# Altium schematic sheet uInverter.SchDoc(uInverter)
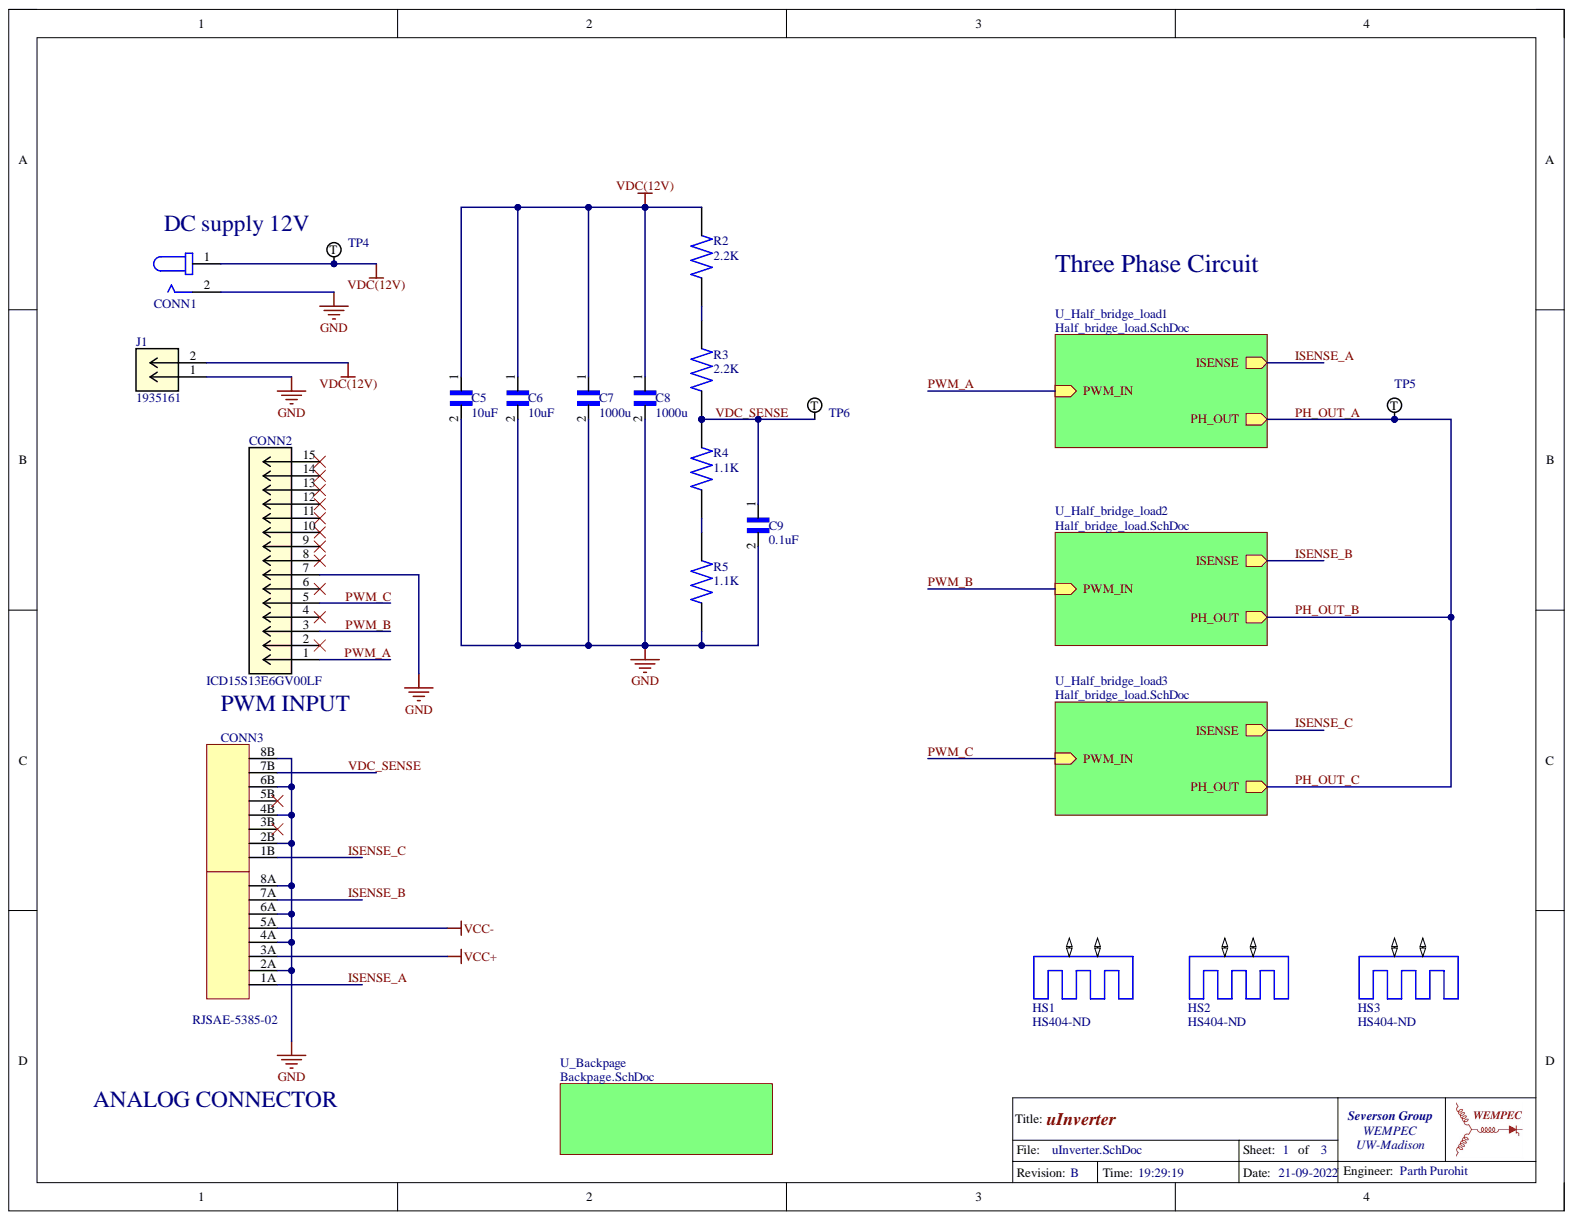
[diagram p0: uInverter.SchDoc]

## Parts
C5  10uF  [0805_CAP]
C6  10uF  [0805_CAP]
C7  1000u  [CAP_PAN_12.5X25_PAN]
C8  1000u  [CAP_PAN_12.5X25_PAN]
C9  0.1uF  [0805_CAP]
CONN1  PJ-037AH  [CUI_PJ-037AH]  (value not drawn)
CONN2  ICD15S13E6GV00LF  [ICD15S13E6GV00LF]
CONN3  RJSAE-5385-02  [CONN_RJSAE538502_AMP]
HS1  Supplier Part Number 1: HS404-ND  [530002]  (hidden comment: 529702B02500G)
HS2  Supplier Part Number 1: HS404-ND  [530002]  (hidden comment: 529702B02500G)
HS3  Supplier Part Number 1: HS404-ND  [530002]  (hidden comment: 529702B02500G)
J1  1935161  [PHOENIX_1935161]
R2  2.2K  [0805_RES]
R3  2.2K  [0805_RES]
R4  1.1K  [0805_RES]
R5  1.1K  [0805_RES]
TP4  Test Point  (value not drawn)
TP5  Test Point  (value not drawn)
TP6  Test Point  (value not drawn)

## Nets
GND: C5.2 C6.2 C7.2 C8.2 C9.2 CONN1.2 CONN2.7 CONN3.2A CONN3.2B CONN3.4A CONN3.4B CONN3.6A CONN3.6B CONN3.8A CONN3.8B J1.1 R5.1
VCC+: CONN3.3A
VCC-: CONN3.5A
VDC(12V): C5.1 C6.1 C7.1 C8.1 CONN1.1 J1.2 R2.2 TP4.1
ISENSE_A: CONN3.1A
ISENSE_B: CONN3.7A
ISENSE_C: CONN3.1B
PH_OUT_A: TP5.1
PWM_A: CONN2.1
PWM_B: CONN2.3
PWM_C: CONN2.5
VDC_SENSE: C9.1 CONN3.7B R3.1 R4.2 TP6.1
NetCONN2_2: CONN2.2
NetCONN2_4: CONN2.4
NetCONN2_6: CONN2.6
NetCONN2_8: CONN2.8
NetCONN2_9: CONN2.9
NetCONN2_10: CONN2.10
NetCONN2_11: CONN2.11
NetCONN2_12: CONN2.12
NetCONN2_13: CONN2.13
NetCONN2_14: CONN2.14
NetCONN2_15: CONN2.15
NetCONN3_3B: CONN3.3B
NetCONN3_5B: CONN3.5B
NetHS1_P$1: HS1.P$1
NetHS1_P$2: HS1.P$2
NetHS2_P$1: HS2.P$1
NetHS2_P$2: HS2.P$2
NetHS3_P$1: HS3.P$1
NetHS3_P$2: HS3.P$2
NetR2_1: R2.1 R3.2
NetR4_1: R4.1 R5.2

## Library data of the parts
C5 C6 = GRM21BR6YA106KE43L: 0805 35 V 10 uF ±10% Tolerance X5R Multilayer Ceramic Capacitor; Manufacturer: Murata; Voltage Rating: 35V; Capacitance: 10uF; Case Code (Imperial): 0805; Case Code (Metric): 2012; Case/Package: 0805; Category: Ceramic Capacitors; Component Kind: Standard; ComponentLink1Description: http://www.farnell.com/datasheets/2079187.pdf?src-supplier=Farnell; ComponentLink1URL: http://www.farnell.com/datasheets/2079187.pdf?src-supplier=Farnell; ComponentLink2Description: http://www.mouser.com/ds/2/281/c02e-2905.pdf?src-supplier=Mouser; ComponentLink2URL: http://www.mouser.com/ds/2/281/c02e-2905.pdf?src-supplier=Mouser; ComponentLink3Description: http://www.farnell.com/datasheets/2079187.pdf?src-supplier=Element14; ComponentLink3URL: http://www.farnell.com/datasheets/2079187.pdf?src-supplier=Element14; ComponentLink4Description: http://search.murata.co.jp/Ceramy/image/img/A01X/G101/ENG/GRM21BR6YA106KE43-01.pdf?src-supplier=Digi-Key; ComponentLink4URL: http://search.murata.co.jp/Ceramy/image/img/A01X/G101/ENG/GRM21BR6YA106KE43-01.pdf?src-supplier=Digi-Key; ComponentLink5Description: http://search.murata.co.jp/Ceramy/image/img/A01X/partnumbering_e_01.pdf?src-supplier=Digi-Key; ComponentLink5URL: http://search.murata.co.jp/Ceramy/image/img/A01X/partnumbering_e_01.pdf?src-supplier=Digi-Key; ComponentLink6Description: http://www.farnell.com/datasheets/2079187.pdf?src-supplier=Newark; ComponentLink6URL: http://www.farnell.com/datasheets/2079187.pdf?src-supplier=Newark; Dielectric: X5R; Height: 1.25mm; Lead Free: Lead Free; Length: 0.079inch; Library Name: Schlib_uInverter.SchLib; Manufacturer Part Number: GRM21BR6YA106KE43L; Max Operating Temperature: 85°C; Min Operating Temperature: -55°C; Mount: Surface Mount; Package Quantity: 3000; Packaging: Tape and Reel; Pricing 1: 3000=0.12929, 6000=0.12211, 9000=0.11493, 15000=0.11134, 30000=0.10775, 75000=0.10056 (USD); REACH SVHC: No SVHC; RoHS: Yes; RoHS Compliant: Yes; Stock 1: 12398; Supplier 1: Digi-Key; Supplier Part Number 1: 490-10514-2-ND; Termination: SMD/SMT; Thickness: 0.057inch; Tolerance: 10%; Voltage Rating (DC): 35V; Weight: 0.000335oz; Width: 0.049inch
C7 C8 = EEU-FM1V102B: Cap Aluminum 1000uF 35V 20% (12.5 X 25mm) Radial 5mm 3190mA 7000 hr 105C Ammo Pack; Manufacturer: Panasonic; Capacitance: 1mF; ESR (Equivalent Series Resistance): 15mR; Lead Diameter: 0.6mm; Life (Hours): 7000Hour; Polarity: Polar; Ripple Current: 3.19A; Case/Package: Radial; Category: Aluminum Electrolytic Capacitors; Component Kind: Standard; ComponentLink1Description: https://datasheet.ciiva.com/11676/aba0000ce108-11676917.pdf?src-supplier=Avnet; ComponentLink1URL: https://datasheet.ciiva.com/11676/aba0000ce108-11676917.pdf?src-supplier=Avnet; Construction: RADIAL; Diameter: 12mm; Height: 25mm; Height - Seated (Max): 1.063inch; Impedance: 15mR; Lead Free: Lead Free; Lead Pitch: 5mm; Length: 25mm; Library Name: Schlib_uInverter.SchLib; Manufacturer Part Number: EEU-FM1V102B; Max Operating Temperature: 105°C; Min Operating Temperature: -40°C; Mount: Through Hole; Package Quantity: 500; Packaging: Tape and Reel; Pricing 1: 1=1.11000, 10=0.84300, 50=0.70060, 100=0.60330 (USD); Radiation Hardening: No; RoHS: Yes; RoHS Compliant: Yes; Series: FM; Stock 1: 691; Supplier 1: Digi-Key; Supplier Part Number 1: P19719CT-ND; Termination: Radial; Tolerance: 20%; Voltage Rating: 35V; Voltage Rating (DC): 35V
C9 = CC0805KRX7R7BB104: Capacitor 0.1uF 16V 0805 SMD X7R; Manufacturer: Yageo; Capacitance: 100nF; Insulation Resistance: 100GR; Tolerance: 10%; Case Code (Imperial): 0805; Case Code (Metric): 2012; Case/Package: 0805; Category: Ceramic Capacitors; Component Kind: Standard; ComponentLink1Description: https://datasheet.ciiva.com/11786/0900766b80d84f1d-11786102.pdf?src-supplier=RSComponents; ComponentLink1URL: https://datasheet.ciiva.com/11786/0900766b80d84f1d-11786102.pdf?src-supplier=RSComponents; ComponentLink2Description: https://datasheet.ciiva.com/8034/1784427-8034017.pdf?src-supplier=Element14; ComponentLink2URL: https://datasheet.ciiva.com/8034/1784427-8034017.pdf?src-supplier=Element14; ComponentLink3Description: https://datasheet.ciiva.com/144/mlccorderingcode-144998.pdf?src-supplier=Digi-Key; ComponentLink3URL: https://datasheet.ciiva.com/144/mlccorderingcode-144998.pdf?src-supplier=Digi-Key; ComponentLink4Description: https://datasheet.ciiva.com/144/mlccorderingcode-144999.pdf?src-supplier=Digi-Key; ComponentLink4URL: https://datasheet.ciiva.com/144/mlccorderingcode-144999.pdf?src-supplier=Digi-Key; ComponentLink5Description: https://datasheet.ciiva.com/145/mlccorderingcode-145000.pdf?src-supplier=Digi-Key; ComponentLink5URL: https://datasheet.ciiva.com/145/mlccorderingcode-145000.pdf?src-supplier=Digi-Key; ComponentLink6Description: https://datasheet.ciiva.com/11465/upy-gphc-x7r-6-3v-to-50v-8-11465289.pdf?src-supplier=Avnet; ComponentLink6URL: https://datasheet.ciiva.com/11465/upy-gphc-x7r-6-3v-to-50v-8-11465289.pdf?src-supplier=Avnet; ComponentLink7Description: https://datasheet.ciiva.com/17436/upy-gphc-x7r-6-3v-to-50v-8-17436617.pdf?src-supplier=Avnet+Europe; ComponentLink7URL: https://datasheet.ciiva.com/17436/upy-gphc-x7r-6-3v-to-50v-8-17436617.pdf?src-supplier=Avnet+Europe; ComponentLink8Description: https://datasheet.ciiva.com/8034/1784427-8034015.pdf?src-supplier=Element14; ComponentLink8URL: https://datasheet.ciiva.com/8034/1784427-8034015.pdf?src-supplier=Element14; ComponentLink9Description: https://datasheet.ciiva.com/15189/getdatasheetpartid-314877-15189221.pdf?src-supplier=Verical; ComponentLink9URL: https://datasheet.ciiva.com/15189/getdatasheetpartid-314877-15189221.pdf?src-supplier=Verical; ComponentLink10Description: https://datasheet.ciiva.com/7978/1489800-7978204.pdf?src-supplier=Element14; ComponentLink10URL: https://datasheet.ciiva.com/7978/1489800-7978204.pdf?src-supplier=Element14; Depth: 1.25mm; Dielectric: X7R; Halogen Free: Halogen Free; Height: 0.85mm; Lead Free: Lead Free; Length: 2mm; Library Name: Schlib_uInverter.SchLib; Manufacturer Part Number: CC0805KRX7R7BB104; Max Operating Temperature: 125°C; Min Operating Temperature: -55°C; Mount: Surface Mount; Number of Pins: 2; Package Quantity: 1; Packaging: Tape and Reel; Pricing 1: 1=0.12000, 10=0.08400, 50=0.04760, 100=0.03950, 500=0.02768, 1000=0.02291 (USD); Radiation Hardening: No; REACH SVHC: No SVHC; Resistor Type: Ceramic; RoHS: Yes; RoHS Compliant: Yes; Series: CC; Stock 1: 1440; Supplier 1: Digi-Key; Supplier Part Number 1: 311-1142-1-ND; Termination: SMD/SMT; Thickness: 0.033inch; Voltage: 16V; Voltage Rating: 16V; Voltage Rating (DC): 16V; Width: 0.049inch
CONN1 = PJ-037AH: CONN PWR JACK 2X5.5MM SOLDER; Manufacturer: CUI; Current Rating: 5A; Gender: Male; Insulation Resistance: 100MR; Number of Conductors: 2; Shielding: Unshielded; Voltage Rating: 24V; Category: Connectors; Color: Black; Component Kind: Standard; ComponentLink1Description: http://www.mouser.com/ds/2/670/pj-037ah-516064.pdf?src-supplier=Mouser; ComponentLink1URL: http://www.mouser.com/ds/2/670/pj-037ah-516064.pdf?src-supplier=Mouser; ComponentLink2Description: https://www.cui.com/product/resource/digikeypdf/pj-037ah.pdf?src-supplier=Digi-Key; ComponentLink2URL: https://www.cui.com/product/resource/digikeypdf/pj-037ah.pdf?src-supplier=Digi-Key; Connector Type: Jack; Contact Gender: Male; Contact Material: Copper; Contact Plating: Nickel; Flammability Rating: UL94 V-0; Housing Color: Black; Housing Material: Thermoplastic; Lead Free: Lead Free; Manufacturer Part Number: PJ-037AH; Max Operating Temperature: 85°C; Min Operating Temperature: -25°C; Mount: Through Hole; Orientation: Horizontal; Package Quantity: 500; Pricing 1: 1=0.71000, 10=0.66600, 25=0.59920, 50=0.53280, 100=0.51060, 250=0.46620, 500=0.44400, 1000=0.36630, 2500=0.33300 (USD); RoHS: Yes; RoHS Compliant: Yes; Series: -; Stock 1: 11304; Supplier 1: Digi-Key; Supplier Part Number 1: CP-037AH-ND; Termination: Solder; Library Name: Schlib_uInv01.SchLib
CONN2 = ICD15S13E6GV00LF: Conn High Density D-Sub SKT 15 POS 2.28mm Solder RA Thru-Hole 15 Terminal 1 Port; Manufacturer: Amphenol FCI; Body Material: Metal,Steel; Connector Type: D-Sub,Receptacle,Signal; Current Rating: 3A; Gender: Female; Mating Cycles: 500; Max Supply Voltage (AC): 300V; Number of Contacts: 15; Number of Ports: 1; Plating: Bright,Tin,Steel,Chrome; Case/Package: Metal; Category: D-Sub Connectors; Color: Black; Component Kind: Standard; ComponentLink1Description: https://datasheet.ciiva.com/22727/1728476-22727809.pdf?src-supplier=Element14; ComponentLink1URL: https://datasheet.ciiva.com/22727/1728476-22727809.pdf?src-supplier=Element14; ComponentLink2Description: https://datasheet.ciiva.com/652/c-cd-0011-652471.pdf?src-supplier=Digi-Key; ComponentLink2URL: https://datasheet.ciiva.com/652/c-cd-0011-652471.pdf?src-supplier=Digi-Key; ComponentLink3Description: https://datasheet.ciiva.com/8058/1728476-8058812.pdf?src-supplier=Element14; ComponentLink3URL: https://datasheet.ciiva.com/8058/1728476-8058812.pdf?src-supplier=Element14; Contact Material: Copper Alloy; Contact Plating: Gold,Tin,Matte; Depth: 21.7mm; Flammability Rating: UL94 V-0; Housing Color: Black; Housing Material: Steel; Lead Free: Lead Free; Length: 30.81mm; Manufacturer Part Number: ICD15S13E6GV00LF; Max Operating Temperature: 125°C; Max Voltage Rating (AC): 300V; Min Operating Temperature: -55°C; Mount: Through Hole; Number of Positions: 15; Number of Rows: 3; Number of Terminals/Pins: 15; Orientation: Right Angle; Package Quantity: 100; Packaging: Bulk; Pitch: 2.28mm; Pricing 1: 1=2.28000, 10=2.06800, 25=1.94120, 50=1.85680, 100=1.77230, 250=1.60352, 500=1.47692, 1000=1.26593, 2500=1.18154 (USD); Radiation Hardening: No; REACH SVHC: No SVHC; RoHS: Yes; Stock 1: 3485; Supplier 1: Digi-Key; Supplier Part Number 1: 609-2802-ND; Termination: Solder; Voltage Rating: 300V; Width: 12.58mm; Library Name: Schlib_uInv01.SchLib
CONN3 = RJSAE-5385-02: CONN MOD JACK 8P8C R/A SHIELDED; Manufacturer: Amphenol Commercial; Current Rating: 1.5A; Direction: Up and Down; Gender: Female; Number of Contacts: 16; Number of Ports: 2; Pitch: 2.54mm; Voltage Rating: 125V; Category: Modular / Ethernet Connectors; Color: Black,Green; Component Kind: Standard; ComponentLink1Description: https://datasheet.ciiva.com/26934/getdatasheetpartid-29521-26934348.pdf?src-supplier=Verical; ComponentLink1URL: https://datasheet.ciiva.com/26934/getdatasheetpartid-29521-26934348.pdf?src-supplier=Verical; Connector Type: Jack; Contact Material: Bronze; Contact Plating: Gold; Depth: 28.15mm; Housing Material: Thermoplastic; Lead Free: Lead Free; Length: 16.51mm; Manufacturer Part Number: RJSAE-5385-02; Max Operating Temperature: 85°C; Min Operating Temperature: -55°C; Mount: Through Hole; Number of Positions Loaded: 16; Number of Rows: 2; Orientation: Right Angle; Package Quantity: 35; Packaging: Tray; Pricing 1: 1=6.67000, 10=6.39000, 25=5.83440, 50=5.55660, 100=5.27880, 250=4.72312, 500=4.44528, 1000=4.01310 (USD); Radiation Hardening: No; RoHS: Yes; RoHS Compliant: Yes; Series: RJSAE; Shielding: Shielded; Stock 1: 254; Supplier 1: Digi-Key; Supplier Part Number 1: RJSAE-5385-02-ND; Termination: Solder; Library Name: Schlib_uInv01.SchLib
HS1-HS3 = 529702B02500G: Heat Sink Passive TO-220 Radial Thru-Hole 2.6C/W Black Anodized; Manufacturer: Aavid Thermalloy; MP: 530002B02500G; Natural Thermal Resistance: 2.6°C/W; Shape: Fins,Rectangular; Thermal Resistance @ Forced Air Flow (100 LFM): 2°C/W; Availability: Unavailable; Case/Package: Radial; Category: Heat Sinks; Color: Black; Component Kind: Standard; ComponentLink1Description: https://datasheet.ciiva.com/15182/getdatasheetpartid-311919-15182633.pdf?src-supplier=Verical; ComponentLink1URL: https://datasheet.ciiva.com/15182/getdatasheetpartid-311919-15182633.pdf?src-supplier=Verical; ComponentLink2Description: https://datasheet.ciiva.com/22641/1699368-22641984.pdf?src-supplier=Element14; ComponentLink2URL: https://datasheet.ciiva.com/22641/1699368-22641984.pdf?src-supplier=Element14; ComponentLink3Description: https://datasheet.ciiva.com/19457/696626-19457620.pdf?src-supplier=Jameco; ComponentLink3URL: https://datasheet.ciiva.com/19457/696626-19457620.pdf?src-supplier=Jameco; ComponentLink4Description: https://datasheet.ciiva.com/6333/aavid-board-level-heatsinks-catalog-6333980.pdf?src-supplier=Digi-Key; ComponentLink4URL: https://datasheet.ciiva.com/6333/aavid-board-level-heatsinks-catalog-6333980.pdf?src-supplier=Digi-Key; ComponentLink5Description: https://datasheet.ciiva.com/14543/70115166-14543413.pdf?src-supplier=Allied+Electronics; ComponentLink5URL: https://datasheet.ciiva.com/14543/70115166-14543413.pdf?src-supplier=Allied+Electronics; Depth: 25.4mm; Finish: Anodized; Height: 63.5mm; Height Off Base: 1inch; Lead Free: Lead Free; Length: 41.91mm; Library Name: Schlib_uInverter.SchLib; Manufacturer Part Number: 529702B02500G; Material: Aluminium,Anodized; MF: Aavid Thermalloy; Mount: Bolt,PC Pin,Solder; Package: None; Package Cooled: TO-220; Package Quantity: 200; Price: None; Pricing 1: 1=6.14000, 10=5.97200, 25=5.64040, 50=5.30860, 100=4.97680, 250=4.64500, 500=4.31320, 1000=4.23026 (USD); Radiation Hardening: No; REACH SVHC: Unknown; RoHS: Yes; RoHS Compliant: Yes; Series: 5300; SnapEDA_Link: https://www.snapeda.com/parts/530002B02500G/Aavid%20Thermalloy/view-part/4792019/?ref=snap; Stock 1: 1035; Supplier 1: Digi-Key; Type: Passive; Width: 1.65inch
J1 = 1935161: Conn Terminal Blocks 2 POS 5mm Solder ST Thru-Hole 16A; Manufacturer: Phoenix Contact; Approvals: UL; Connector Type: Screw; Current: 18A; Current Rating: 17.5A; Max Supply Voltage: 250V; Number of Circuits: 2; Number of Levels: 1; Voltage: 300V; Voltage Rating (DC): 320V; Wire Gauge (Max): 26AWG; Wire Gauge (Min): 12AWG; Category: Terminal Blocks; Color: Green; Component Kind: Standard; ComponentLink1Description: https://datasheet.ciiva.com/15169/getdatasheetpartid-177903-15169663.pdf?src-supplier=Verical; ComponentLink1URL: https://datasheet.ciiva.com/15169/getdatasheetpartid-177903-15169663.pdf?src-supplier=Verical; ComponentLink2Description: https://datasheet.ciiva.com/16047/1935161-16047247.pdf?src-supplier=Onlinecomponents.com; ComponentLink2URL: https://datasheet.ciiva.com/16047/1935161-16047247.pdf?src-supplier=Onlinecomponents.com; ComponentLink3Description: https://datasheet.ciiva.com/824/combiconcompact5-0mmseries-824222.pdf?src-supplier=Digi-Key; ComponentLink3URL: https://datasheet.ciiva.com/824/combiconcompact5-0mmseries-824222.pdf?src-supplier=Digi-Key; Contact Material: Copper,Tin; Depth: 9mm; Flammability Rating: UL94 V-0; Height: 11.3mm; Housing Color: Green; Housing Material: Polyamide; Insulation Material: Nylon; Lead Free: Lead Free; Length: 9mm; Manufacturer Part Number: 1935161; Material: Nylon; Max Current Rating: 16A; Mount: Through Hole; Number of Contacts: 2; Number of Pins: 2; Number of Poles: 2; Number of Positions: 2; Number of Positions Per Level: 2; Number of Rows: 1; Number of Ways: 2; Orientation: Straight; Package Quantity: 250; Packaging: Bulk; Pitch: 5mm; Pricing 1: 1=0.43000, 10=0.41400, 50=0.39600, 100=0.38520, 250=0.36000, 500=0.33120, 1000=0.30600 (USD); Radiation Hardening: No; REACH SVHC: Unknown; RoHS: Yes; RoHS Compliant: Yes; Series: COMBICON PT; Stock 1: 82071; Supplier 1: Digi-Key; Supplier Part Number 1: 277-1667-ND; Termination: Solder; Voltage Rating: 250V; Weight: 0.067021oz; Width: 14.9mm; Wire/Cable Gauge: 26AWG; Library Name: Schlib_uInv01.SchLib
R2 R3 = RCS08052K20FKEA: Res Thick Film 0805 2.2K Ohm 1% 0.4W; Manufacturer: Vishay Dale; Max Power Dissipation: 400mW; Resistance: 2.2kR; Temperature Coefficient: 100ppm/°C; Case Code (Imperial): 0805; Case Code (Metric): 2012; Case/Package: 0805; Category: Chip SMD Resistors; Component Kind: Standard; ComponentLink1Description: http://www.vishay.com/docs/20065/rcse3.pdf?src-supplier=Digi-Key; ComponentLink1URL: http://www.vishay.com/docs/20065/rcse3.pdf?src-supplier=Digi-Key; Features: Automotive AEC-Q200; Height: 0.02inch; Length: 0.079inch; Library Name: Schlib_uInverter.SchLib; Manufacturer Part Number: RCS08052K20FKEA; Max Operating Temperature: 155°C; Min Operating Temperature: -55°C; Number of Terminations: 2; Packaging: Cut Tape; Pricing 1: 1=0.26000, 10=0.21800, 100=0.08510, 1000=0.03560, 2500=0.03264 (USD); Resistor Type: Thick Film; RoHS: Yes; Stock 1: 5528; Supplier 1: Digi-Key; Supplier Part Number 1: 541-2841-1-ND; Tolerance: 1%; Width: 0.049inch
R4 R5 = ESR10EZPF1101: Res Thick Film 0805 1.1K Ohm 1% 0.4W; Manufacturer: Rohm; Max Power Dissipation: 400mW; Resistance: 1.1kR; Temperature Coefficient: 100ppm/°C; Case Code (Imperial): 0805; Case Code (Metric): 2012; Case/Package: 0805; Category: Chip SMD Resistors; Component Kind: Standard; ComponentLink1Description: https://datasheet.ciiva.com/2470/esr-2470093.pdf?src-supplier=Digi-Key; ComponentLink1URL: https://datasheet.ciiva.com/2470/esr-2470093.pdf?src-supplier=Digi-Key; ComponentLink2Description: https://datasheet.ciiva.com/2470/esr-2470094.pdf?src-supplier=Digi-Key; ComponentLink2URL: https://datasheet.ciiva.com/2470/esr-2470094.pdf?src-supplier=Digi-Key; ComponentLink3Description: https://datasheet.ciiva.com/2470/esr-2470095.pdf?src-supplier=Digi-Key; ComponentLink3URL: https://datasheet.ciiva.com/2470/esr-2470095.pdf?src-supplier=Digi-Key; ComponentLink4Description: https://datasheet.ciiva.com/16212/esr10ezpd-16212063.pdf?src-supplier=Future+Electronics; ComponentLink4URL: https://datasheet.ciiva.com/16212/esr10ezpd-16212063.pdf?src-supplier=Future+Electronics; Features: Automotive AEC-Q200; Height: 0.026inch; Lead Free: Lead Free; Length: 0.079inch; Library Name: Schlib_uInverter.SchLib; Manufacturer Part Number: ESR10EZPF1101; Max Operating Temperature: 155°C; Min Operating Temperature: -55°C; Number of Terminations: 2; Package Quantity: 1; Packaging: Tape and Reel; Power Rating: 400mW; Pricing 1: 1=0.18000, 10=0.15300, 100=0.05980, 1000=0.02505, 2500=0.02296 (USD); Resistor Type: Thick Film; RoHS: Yes; RoHS Compliant: Yes; Series: ESR; Size Code: 0805; Stock 1: 6361; Supplier 1: Digi-Key; Supplier Part Number 1: RHM1.10KAECT-ND; Tolerance: 1%; Width: 0.049inch
TP4-TP6 = Test Point 36-5005ND: Conn Test Point SKT 1 POS ST Thru-Hole 1 Port; Body Material: PBT; Hole Diameter: 1.6mm; Inside Diameter: 1.78mm; Material - Insulation: No Insulation; Number of Ports: 1; Outside Diameter: 3.18mm; Category: Test and Measurement; Color: Natural; Component Kind: Standard; ComponentLink1Description: http://www.te.com/commerce/DocumentDelivery/DDEController?Action=srchrtrv&DocNm=1773266&DocType=DS&DocLang=English; ComponentLink1URL: http://www.te.com/commerce/DocumentDelivery/DDEController?Action=srchrtrv&DocNm=1773266&DocType=DS&DocLang=English; ComponentLink2Description: https://datasheet.ciiva.com/11744/0900766b8009b7c7-11744948.pdf?src-supplier=RSComponents; ComponentLink2URL: https://datasheet.ciiva.com/11744/0900766b8009b7c7-11744948.pdf?src-supplier=RSComponents; ComponentLink3Description: https://datasheet.ciiva.com/2917/m60-2p60-2917633.pdf?src-supplier=Digi-Key; ComponentLink3URL: https://datasheet.ciiva.com/2917/m60-2p60-2917633.pdf?src-supplier=Digi-Key; ComponentLink4Description: https://datasheet.ciiva.com/16508/m60-2p60-16508073.pdf?src-supplier=Future+Electronics; ComponentLink4URL: https://datasheet.ciiva.com/16508/m60-2p60-16508073.pdf?src-supplier=Future+Electronics; ComponentLink5Description: https://datasheet.ciiva.com/1454/1703983-1454828.pdf?src-supplier=Element14; ComponentLink5URL: https://datasheet.ciiva.com/1454/1703983-1454828.pdf?src-supplier=Element14; ComponentLink6Description: https://datasheet.ciiva.com/16883/70182825-16883781.pdf?src-supplier=Allied+Electronics; ComponentLink6URL: https://datasheet.ciiva.com/16883/70182825-16883781.pdf?src-supplier=Allied+Electronics; Contact Material: Bronze; Contact Plating: Silver,Tin; Flammability Rating: UL94 V-0; Height: 0.35inch; Housing Color: Red; Lead Free: Lead Free; Length: 8.89mm; Material: Nylon,Bronze,Silver; Material - Body: -; Mount: PCB,Through Hole; Mounting Type: Surface Mount; Orientation: Straight; Package Quantity: 100; Packaging: Cut Tape (CT); Part Status: Active; Plating: -; Pricing 1: 1=0.22, 10=0.206, 25=0.1756, 50=0.1536, 100=0.1426, 250=0.12616, 500=0.12068, 1000=0.09874 (USD); Pricing 2: 1=0.42000, 25=0.34080, 100=0.30150, 250=0.28304, 500=0.25230, 1000=0.19884, 2500=0.18180, 5000=0.17044 (USD); REACH SVHC: No SVHC; RoHS: RoHS Compliant; RoHS Compliant: Yes; Series: THM; Size / Dimension: 0.063" x 0.031" x 0.045" (1.60mm x 0.80mm x 1.15mm); Stock 1: 136646; Stock 2: 147226; Supplier 1: Digi-Key; Supplier Part Number 1: 36-5005-ND; Termination: Solder; Type: PC Test Point; Library Name: Schlib_uInv01.SchLib
